# Altium schematic sheet Schematic.SchDoc
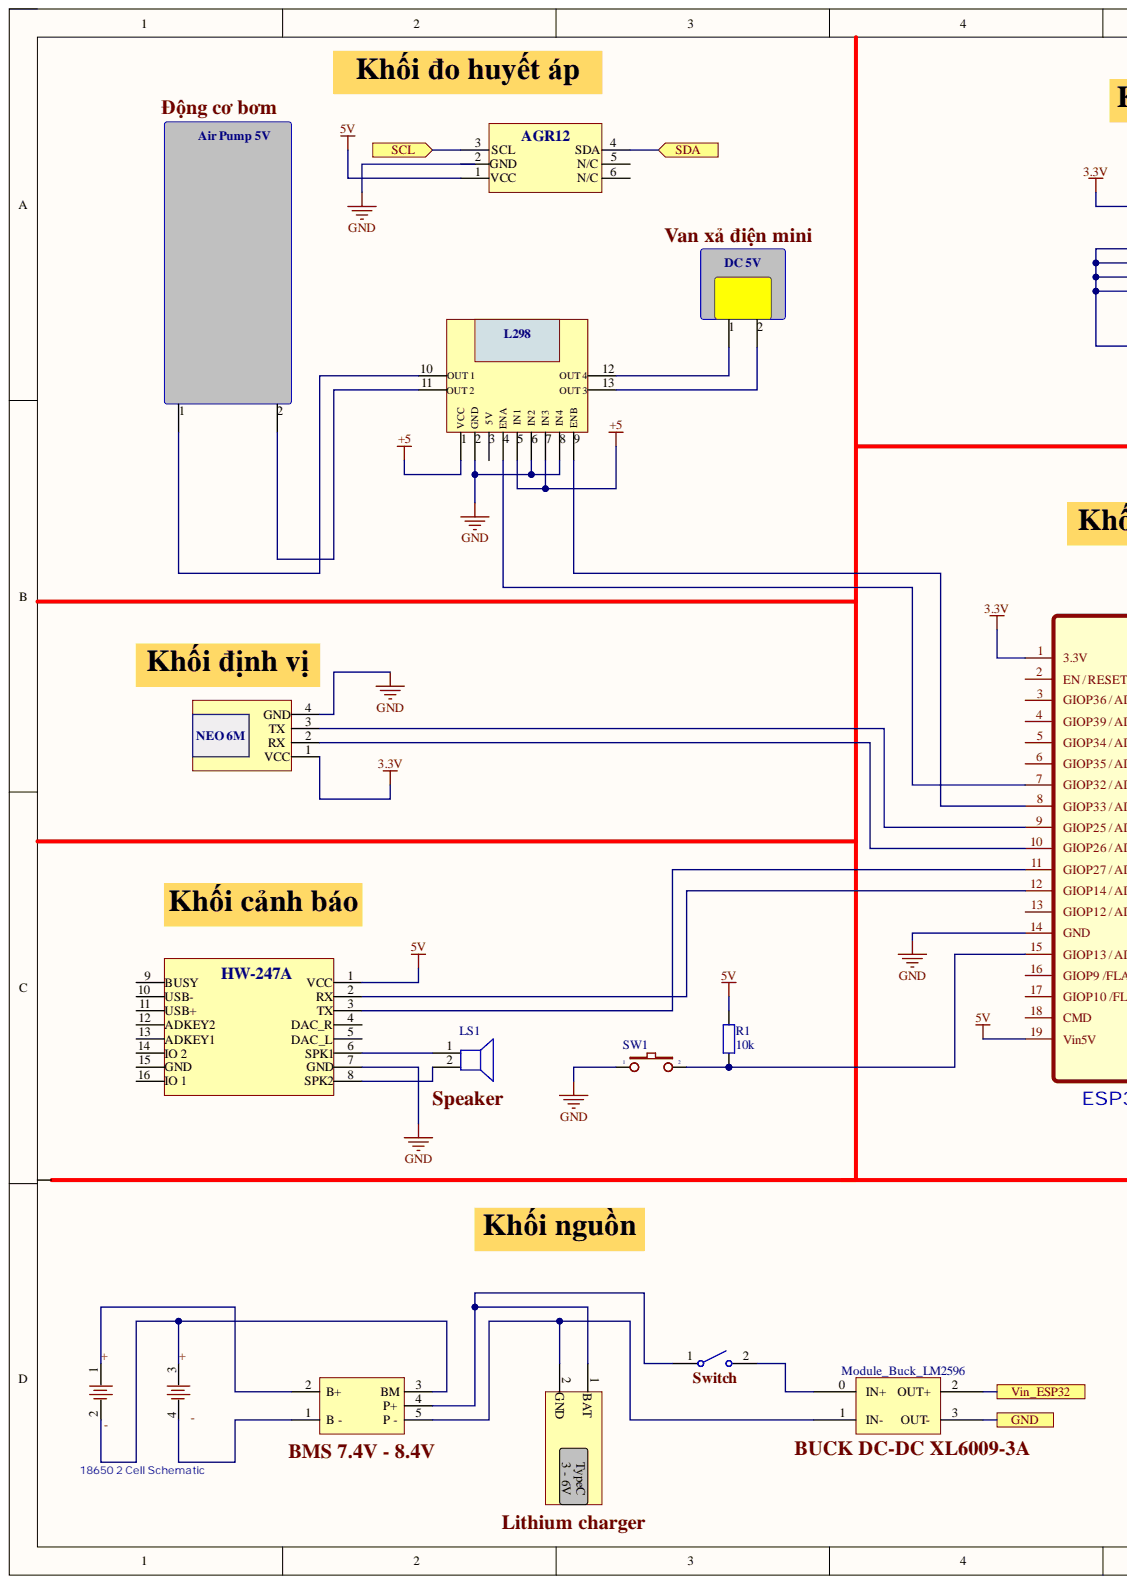
[diagram p0: Schematic.SchDoc, left]
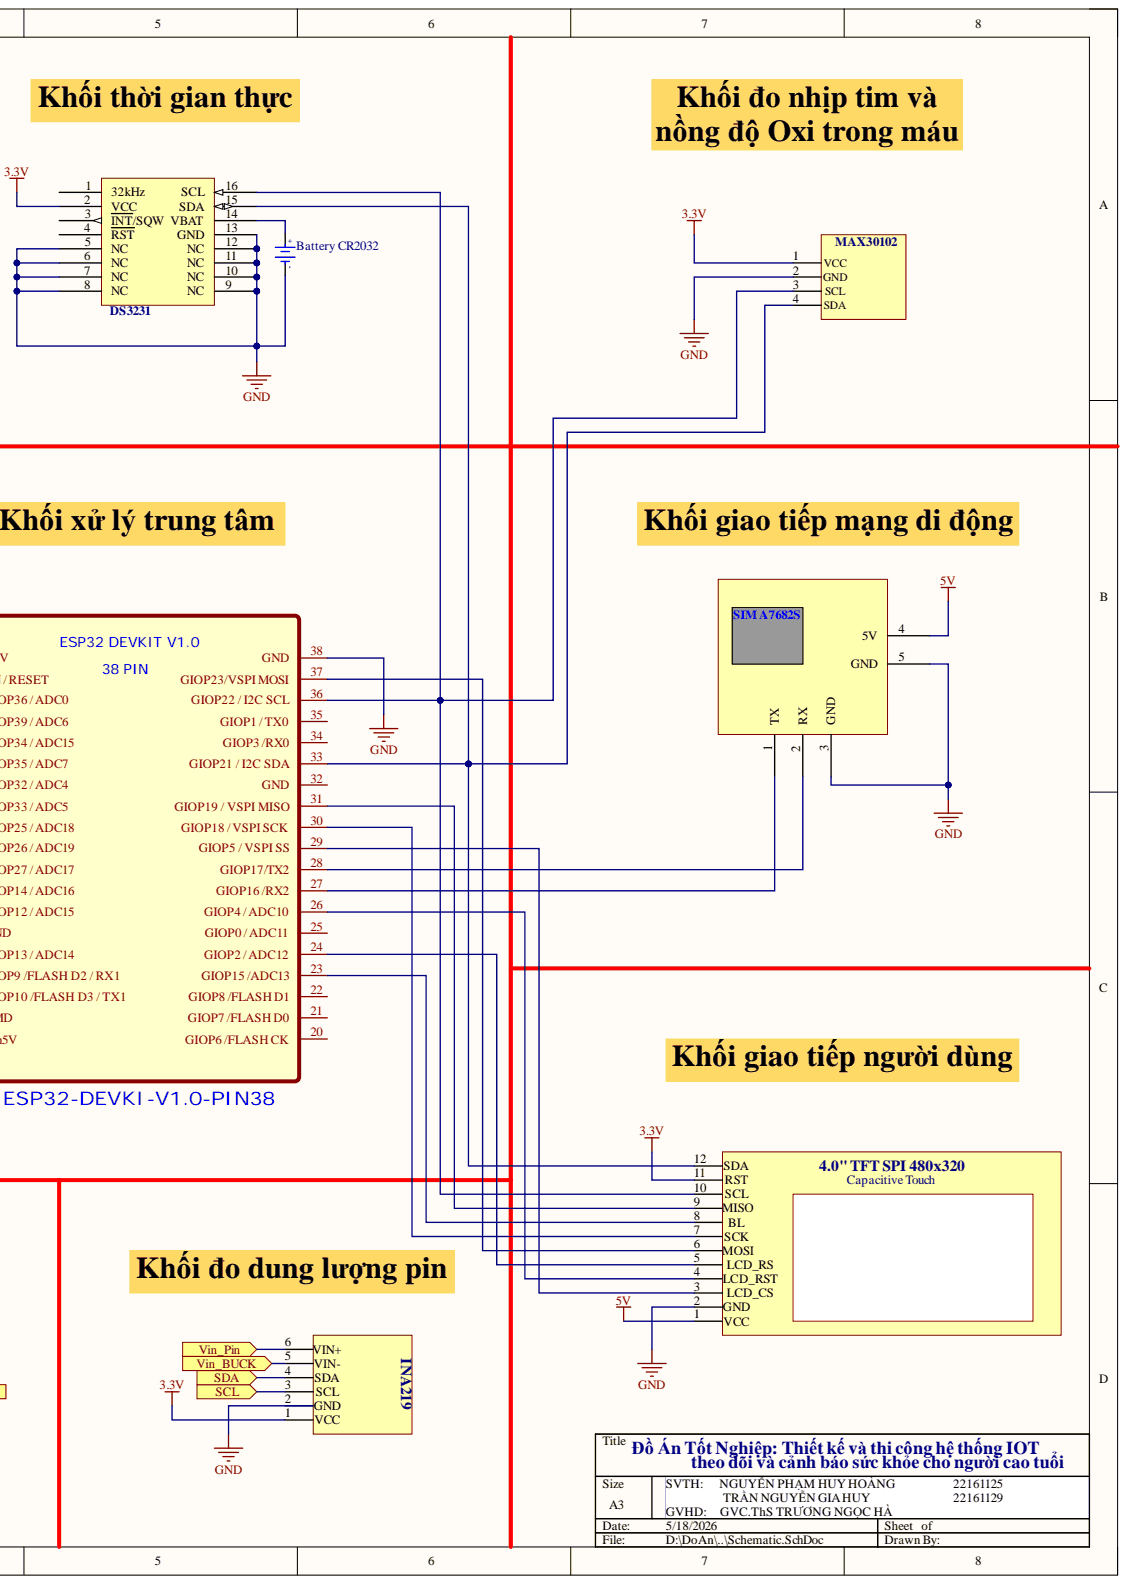
[diagram p1: Schematic.SchDoc, right]

## Parts
LS1  Speaker  [SPEAKER]
Module_Buck_LM2596  BUCK DC-DC XL6009-3A  [module_buck_LM2596]
R1  10k  [R1/4W]
SW1  (no value)  [SW2]
  ESP32-DEVKI-V1.0-PIN38  [ESP32-DEVKIT-V1.0-38PIN]
  (no value)
  (no value)  [SL3016]
  Battery CR2032  [PIN3V]
  Single-Pole, Single-Throw Switch  (value not drawn)
  18650 2 Cell Schematic  [18650 2 CELL HOLDER LIB]

## Ports
GND, SCL, SDA, Vin_BUCK, Vin_ESP32, Vin_Pin

## Nets
3.3V: .1 .2
5V: R1.2 .4 .19(Vin5V)
GND: SW1.1 .2 .3 .5 .6 .7 .8 .9 .10 .11 .12 .13 .14 .38(GND)
Net_1: .1 .14 .27(GIOP16_/RX2)
Net_2: Module_Buck_LM2596.0(IN+) .2 .28(GIOP17/TX2)
Net_3: .3
Net_4: .4
Net_5: .5
Net_6: .6
Net_7: .7
Net_8: .8
Net_9: .9
Net_10: .10
Net_11: .11
Net_12: .12
Net_13: .13
Net_15: R1.1 SW1.2 .15 .33(GIOP21_/_I2C_SDA)
Net_16: .16 .36(GIOP22_/_I2C_SCL)
Net_17: .17(GIOP10_/FLASH_D3_/_TX1)
Net_18: .18(CMD)
Net_20: .20(GIOP6_/FLASH_CK)
Net_21: .21(GIOP7_/FLASH_D0)
Net_22: .22(GIOP8_/FLASH_D1)
Net_23: .23(GIOP15_/ADC13)
Net_24: .24(GIOP2_/_ADC12)
Net_25: .25(GIOP0_/_ADC11)
Net_26: .26(GIOP4_/_ADC10)
Net_29: .29(GIOP5_/_VSPI_SS)
Net_30: .30(GIOP18_/_VSPI_SCK)
Net_31: .31(GIOP19_/_VSPI_MISO)
Net_32: .32(GND)
Net_34: .34(GIOP3_/RX0)
Net_35: .35(GIOP1_/_TX0)
Net_37: .37(GIOP23/VSPI_MOSI)
+: .1 .2 .3
Vin_ESP32: Module_Buck_LM2596.2(OUT+) ; port Vin_ESP32
NetModule_Buck_LM2596_3: Module_Buck_LM2596.3(OUT-) ; port GND
1:
2:
-:
N00001: ; port SDA
N00002: ; port SCL
N00003: ; port Vin_Pin
N00004: ; port Vin_BUCK

Not connected: LS1 1 2; Module_Buck_LM2596 1(IN-)

## Library data of the parts
LS1 = Speaker: Loudspeaker; Component Kind: Standard; LatestRevisionDate: 17-Jul-2002; LatestRevisionNote: Re-released for DXP Platform.; Library Name: Altium_Devzone.SchLib; PackageReference: PIN2; Published: 24-Mar-1999; Publisher: Altium Limited
Module_Buck_LM2596 = Module_buck_LM2596: module buck DC - DC LM2596; Component Kind: Standard; Library Name: Altium_Devzone.SchLib
R1 = R1/4W: Resistor 1/4W; Value: R1/4W - 1K; Component Kind: Standard; Library Name: My Library.SchLib
SW1 = SW2: Switch button 2 pin; Value: SW chip 2 pin; Component Kind: Standard; Library Name: Altium_Devzone.SchLib
 = SIM800A_Module: SIM800A Module; Component Kind: Standard; Library Name: Altium_Devzone.SchLib
 = DS3231: Component Kind: Standard; Library Name: My Library.SchLib
 = PIN 3V: Battery 3VDC; Value: PIN 3V; Component Kind: Standard; Library Name: Altium_Devzone.SchLib
 = SPST SW: closed: 0; Rclosed: 1m; Ropen: 1Meg; SimulationGenericComponent: True; Component Kind: Standard; Description: Single-Pole, Single-Throw Switch; Library Name: Simulation Generic Components.IntLib; Simulation: SPST_SWITCH
 = 18650 2 Cell Schematic Lib: Component Kind: Standard; Library Name: Altium_Devzone.SchLib
 = ESP32-DEVKI-V1.0-PIN38: Component Kind: Standard; Library Name: My Library.SchLib
